# Altium schematic sheet Sheet1.SchDoc
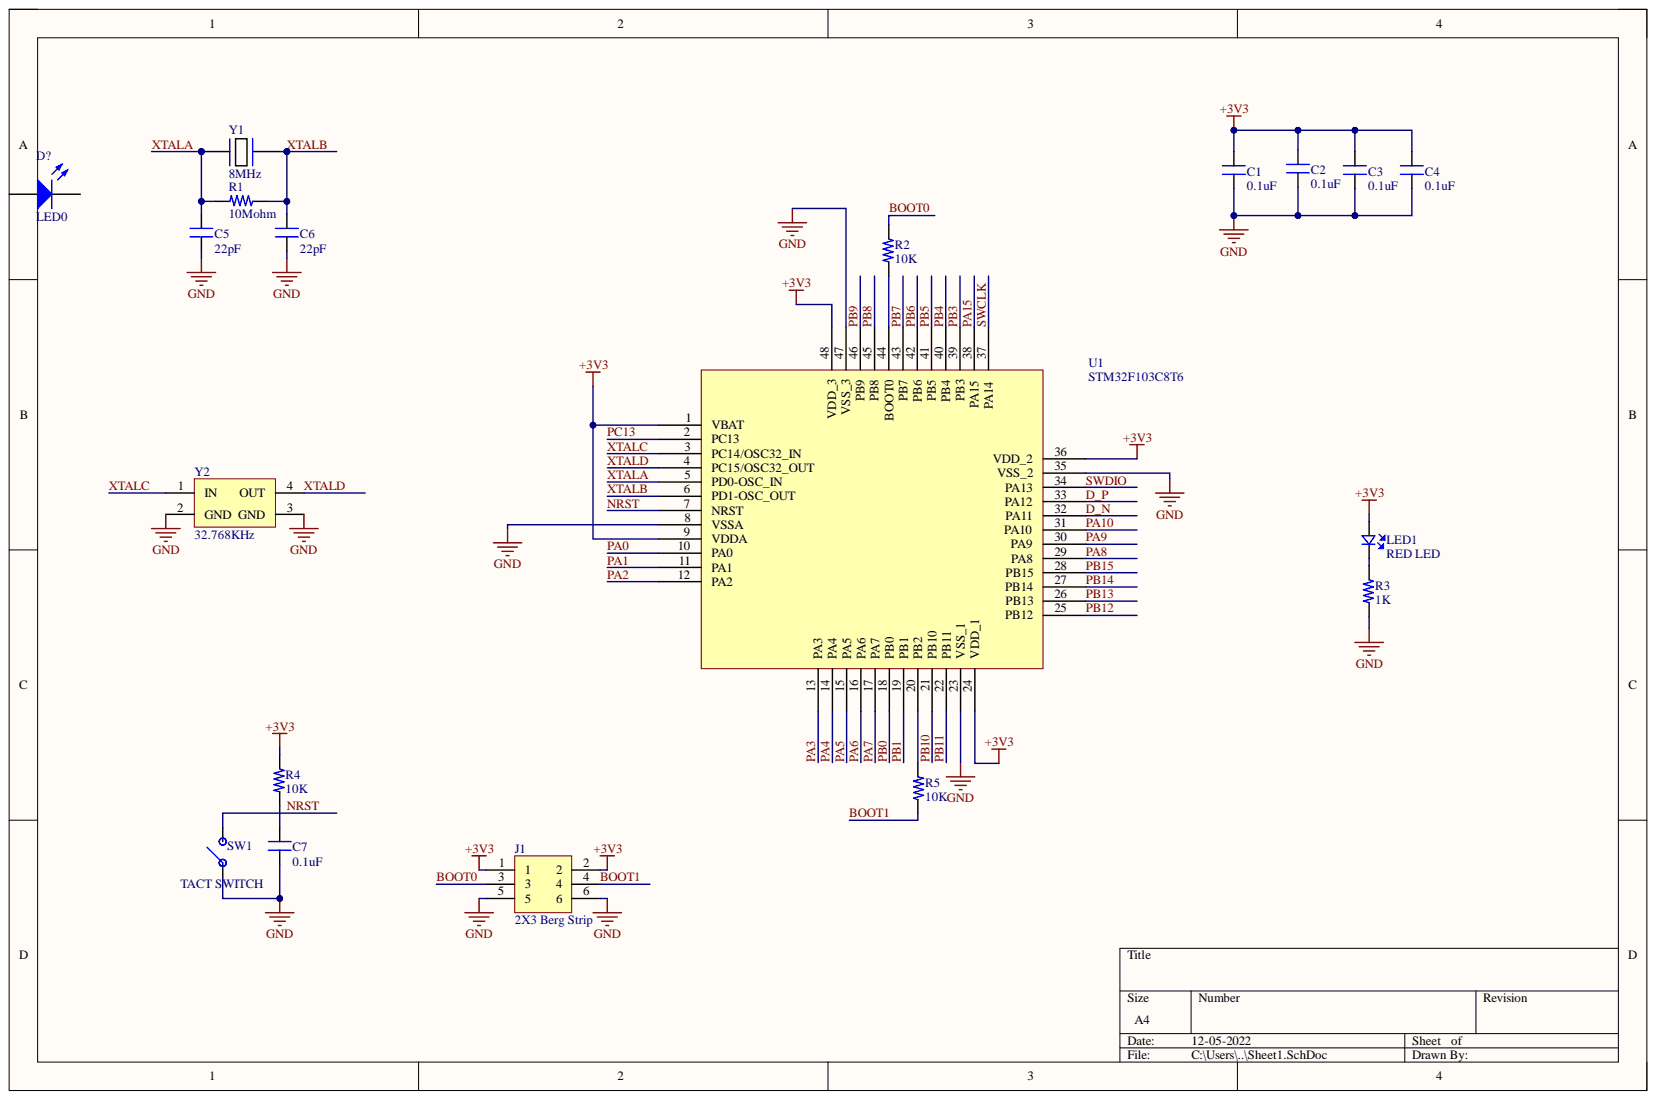
[diagram p0: Sheet1.SchDoc]

## Parts
C1  0.1uF  [CAP_0805]
C2  0.1uF  [CAP_0805]
C3  0.1uF  [CAP_0805]
C4  0.1uF  [CAP_0805]
C5  22pF  [CAP_0805]
C6  22pF  [CAP_0805]
C7  0.1uF  [CAP_0805]
D?  LED0  [LED-0]
J1  2X3 Berg Strip  [CON6_100_59D78_TH_ST_Duplicate]
LED1  RED LED  [LED_0805]
R1  10Mohm  [RES_0805]
R2  10K  [RES_0805]
R3  1K  [RES_0805]
R4  10K  [RES_0805]
R5  10K  [RES_0805]
SW1  TACT SWITCH  [SW_342_SMD]
U1  STM32F103C8T6  [LQFP-48_19.6_393_58X11_SMD]
Y1  8MHz  [XTAL_314_240X47_SMD]
Y2  32.768KHz  [XTAL_MC-405 32.7680K-G3]

## Nets
+3V3: C1.2 C2.2 C3.2 C4.2 J1.1 J1.2 LED1.A R4.2 U1.1 U1.9 U1.24 U1.36 U1.48
GND: C1.1 C2.1 C3.1 C4.1 C5.1 C6.1 C7.1 J1.5 J1.6 R3.1 SW1.1 U1.8 U1.23 U1.35 U1.47 Y2.2 Y2.3
BOOT0: J1.3 R2.2
BOOT1: J1.4 R5.2
D_N: U1.32
D_P: U1.33
NRST: SW1.2 U1.7
PA0: U1.10
PA1: U1.11
PA2: U1.12
PA3: U1.13
PA4: U1.14
PA5: U1.15
PA6: U1.16
PA7: U1.17
PA8: U1.29
PA9: U1.30
PA10: U1.31
PA15: U1.38
PB0: U1.18
PB1: U1.19
PB3: U1.39
PB4: U1.40
PB5: U1.41
PB6: U1.42
PB7: U1.43
PB8: U1.45
PB9: U1.46
PB10: U1.21
PB11: U1.22
PB12: U1.25
PB13: U1.26
PB14: U1.27
PB15: U1.28
PC13: U1.2
SWCLK: U1.37
SWDIO: U1.34
XTALA: C5.2 R1.1 U1.5 Y1.1
XTALB: C6.2 R1.2 U1.6 Y1.2
XTALC: U1.3 Y2.1
XTALD: U1.4 Y2.4
NetC7_2: C7.2 R4.1
NetLED1_K: LED1.K R3.2
NetR2_1: R2.1 U1.44
NetR5_1: R5.1 U1.20

Not connected: D? 1 2

## Library data of the parts
C1-C4 C7 = CAP_0.1uF-50V-5%-0805: 0.1µF ±5% 50V Ceramic Capacitor X7R 0805 (2012 Metric); Manufacturer: KEMET; Manufacturing Part Number: C0805C104J5RACTU; Case/Package: 0805 (2012 Metric); Component Kind: Standard; Library Name: STM_SCH_LIB.SchLib; Supplier-1: Digikey; Supplier Part Number-1: 399-1171-1-ND
C5 C6 = CAP_22pF-50V-5%-0805: 22pF ±5% 50V Ceramic Capacitor C0G, NP0 0805 (2012 Metric); Manufacturer: KEMET; Manufacturer Part Number: C0805C220J5GACTU; Case/Package: 0805; Component Kind: Standard; Library Name: STM_SCH_LIB.SchLib; Supplier-1: Digikey; Supplier Part Number-1: 399-1113-1-ND
D? = LED0: Typical INFRARED GaAs LED; Component Kind: Standard; LatestRevisionDate: 08-Jul-2005; LatestRevisionNote: Mech Layer 1 removed.; Library Name: Miscellaneous Devices.IntLib; PackageDescription: LED; 2 Leads; PackageReference: LED-0; Published: 8-Jun-2000; Simulation: LED0; Publisher: Altium Limited
J1 = CON-BS-PLG_2X3-100-TH-ST: 6 pin, 2 row, 2.54mm pitch bergstrip; Manufacturer: NA; Case/Package: TH; Component Kind: Standard; Library Name: STM_SCH_LIB.SchLib; Manufacturer Part Number: NA; Supplier-1: NA; Supplier Part Number-1: NA
LED1 = LED-RED_10mA-0805: Red 621nm LED Indication - Discrete 2V 0805 (2012 Metric); Manufacturer: Lite-On Inc.; Manufacturer Part Number: LTST-C170EKT; Case/ Package: 0805; Component Kind: Standard; Library Name: STM_SCH_LIB.SchLib; Supplier-1: Digikey; Supplier Part Number-1: 160-1178-1-ND
R1 = RES_10MOHM-0.125W-1%-0805: 10 MOhms ±1% 0.125W, 1/8W Chip Resistor 0805 (2012 Metric) Automotive AEC-Q200, High Voltage Thick Film; Manufacturer: Rohm Semiconductor; Manufacturing Part Number: KTR10EZPF1005; Case/Package: 0805 (2012 Metric); Component Kind: Standard; Library Name: STM_SCH_LIB.SchLib; Supplier-1: Digikey; Supplier Part Number-1: RHM10.0MAHCT-ND
R2 R4 R5 = RES_10K-0.125W-1%-0805: 10 kOhms ±1% 0.125W, 1/8W Chip Resistor 0805 (2012 Metric) Moisture Resistant Thick Film; Manufacturer: Yageo; Manufacturing Part Number: RC0805FR-0710KL; Case/Package: 0805; Component Kind: Standard; Library Name: STM_SCH_LIB.SchLib; Supplier-1: Digikey; Supplier Part Number-1: 311-10.0KCRCT-ND
R3 = RES_1K-0.125W-1%-0805: 1 kOhms ±1% 0.125W, 1/8W Chip Resistor 0805 (2012 Metric) Moisture Resistant Thick Film; Manufacturer: 垈㎵á; Manufacturer Part Number: RC0805FR-071KL; Case/Package: 0805; Component Kind: Standard; Library Name: STM_SCH_LIB.SchLib; Supplier-1: Digikey; Supplier Part Number-1: 311-1.00KCRCT-ND
SW1 = SW_KSR223GLFG-SMD: Tactile Switch SPST-NO Top Actuated Surface Mount; Manufacturer: C&K; Case/Package: SMD; Component Kind: Standard; Library Name: STM_SCH_LIB.SchLib; Manufacturing Part Number: KSR223GLFG; Supplier-1: Digikey; Supplier Part Number-1: 401-1704-1-ND
U1 = IC-STM32F103C8T6 _1: ARM® Cortex®-M3 STM32F1 Microcontroller IC 32-Bit 72MHz 64KB (64K x 8) FLASH; Manufacturer: STMicroelectronics; Case/Package: 48-LQFP; Component Kind: Standard; Library Name: STM_SCH_LIB.SchLib; Manufacturer Part Number: STM32F103C8T6; Supplier-1: Digikey; Supplier Part Number-1: 497-6063-ND
Y1 = XTAL_8MHZ-LFXTAL030234: Crystals 8MHz 22pF -20C 70C; Manufacturer: IQD Frequency Products; Manufacturing Part Number: LFXTAL030234Reel; Case/Package: SMD; Component Kind: Standard; Library Name: STM_SCH_LIB.SchLib; Supplier-1: Mouser; Supplier Part Number-1: 449-LFXTAL030234REEL
Y2 = XTAL_32.768KHz-MC-405 32.7680K-G3: Crystals SMD AT XTL MHZ +/-50PPM 12.5 PF 250 T/R; Manufacturer: Epson; Manufacturing Part Number: MC-405 32.7680K-G3: ROHS; Case/Package: SMD; Component Kind: Standard; Library Name: STM_SCH_LIB.SchLib; Supplier-1: Mouser; Supplier Part Number-1: 732-MC405327680KG3RS
